# Altium schematic sheet Root.SchDoc
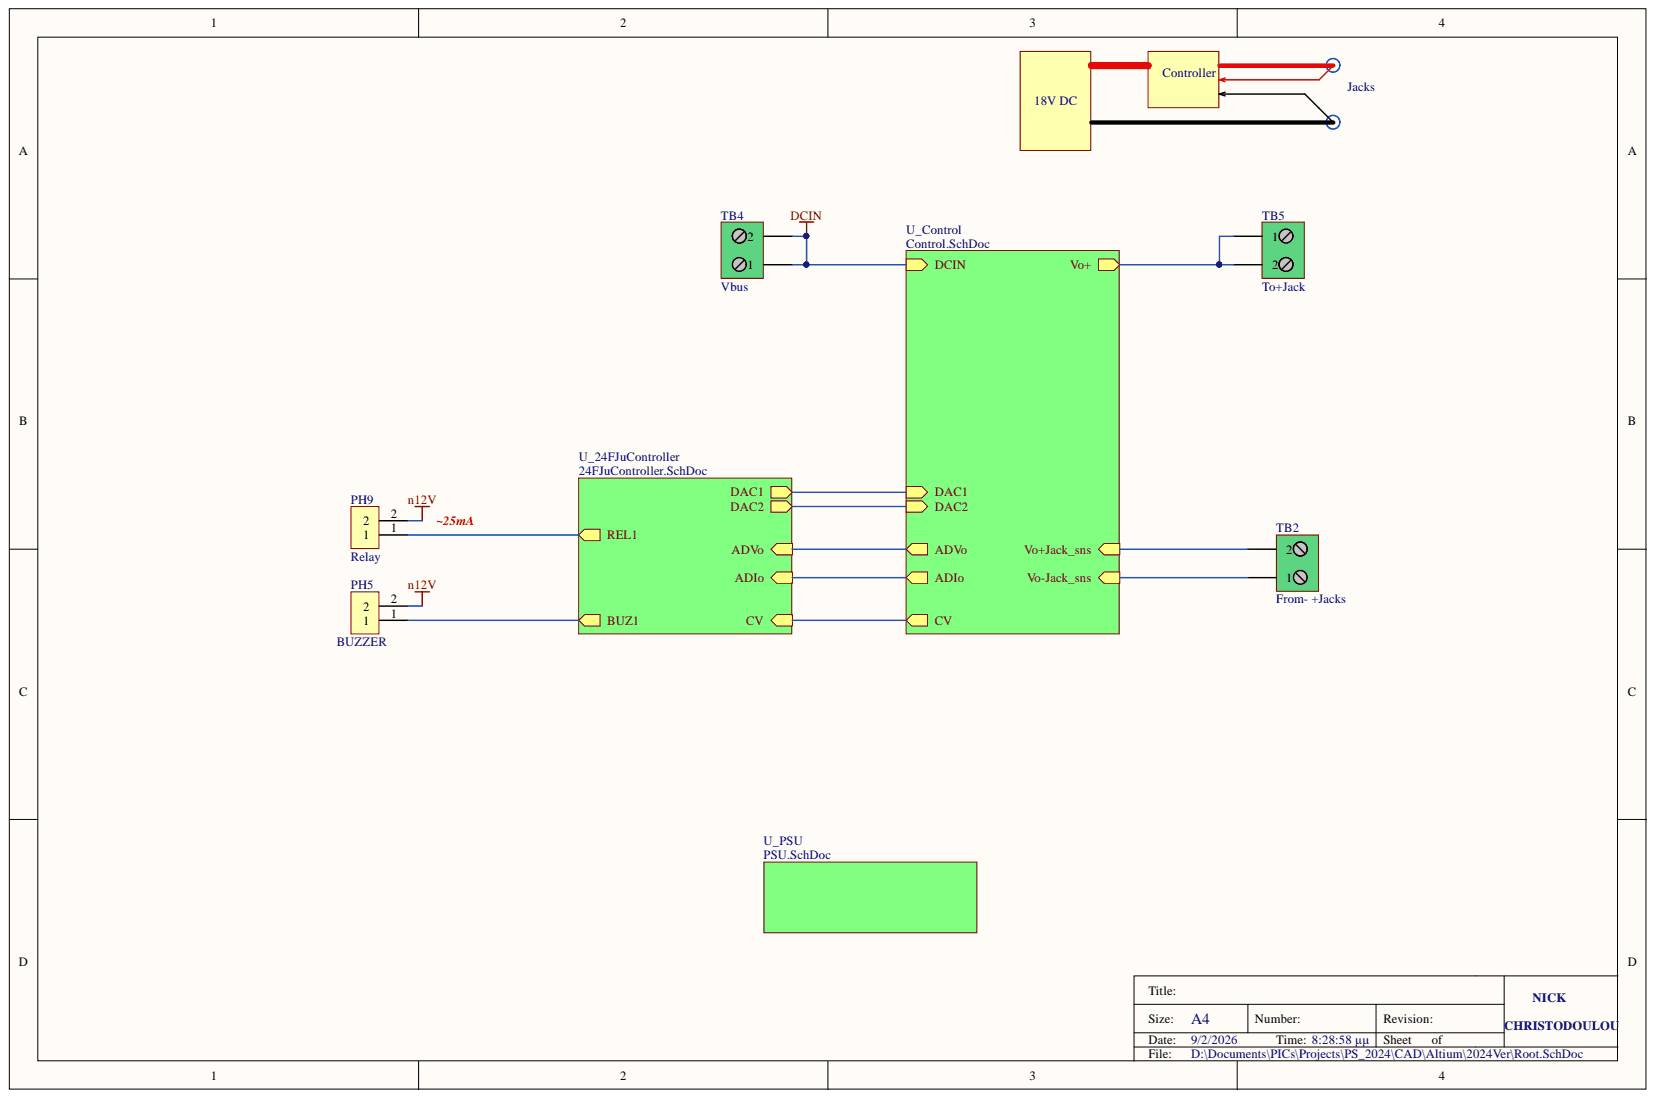
[diagram p0: Root.SchDoc]

## Parts
PH5  BUZZER  [HDR1X2]
PH9  Relay  [HDR1X2]
TB2  From- +Jacks  [TB_2]
TB4  Vbus  [TB_2]
TB5  To+Jack  [TB_2]

## Nets
DCIN: TB4.1 TB4.2
n12V: PH5.2 PH9.2
NetPH5_1: PH5.1
NetPH9_1: PH9.1
NetTB2_1: TB2.1
NetTB2_2: TB2.2
NetTB5_1: TB5.1 TB5.2
N00002:
N00003:
N00004:
N00005:
N00006:

## Library data of the parts
PH5 PH9 = Header1X2: One row 2 pins Header; Component Kind: Standard; Library Name: MyAltiumLib.IntLib
TB2 TB4 TB5 = CON2: PCB screw terminal block 2 pins; Component Kind: Standard; Library Name: MyAltiumLib.IntLib
